# Altium schematic sheet 09_LCD&CAMERA&GPIO.SchDoc
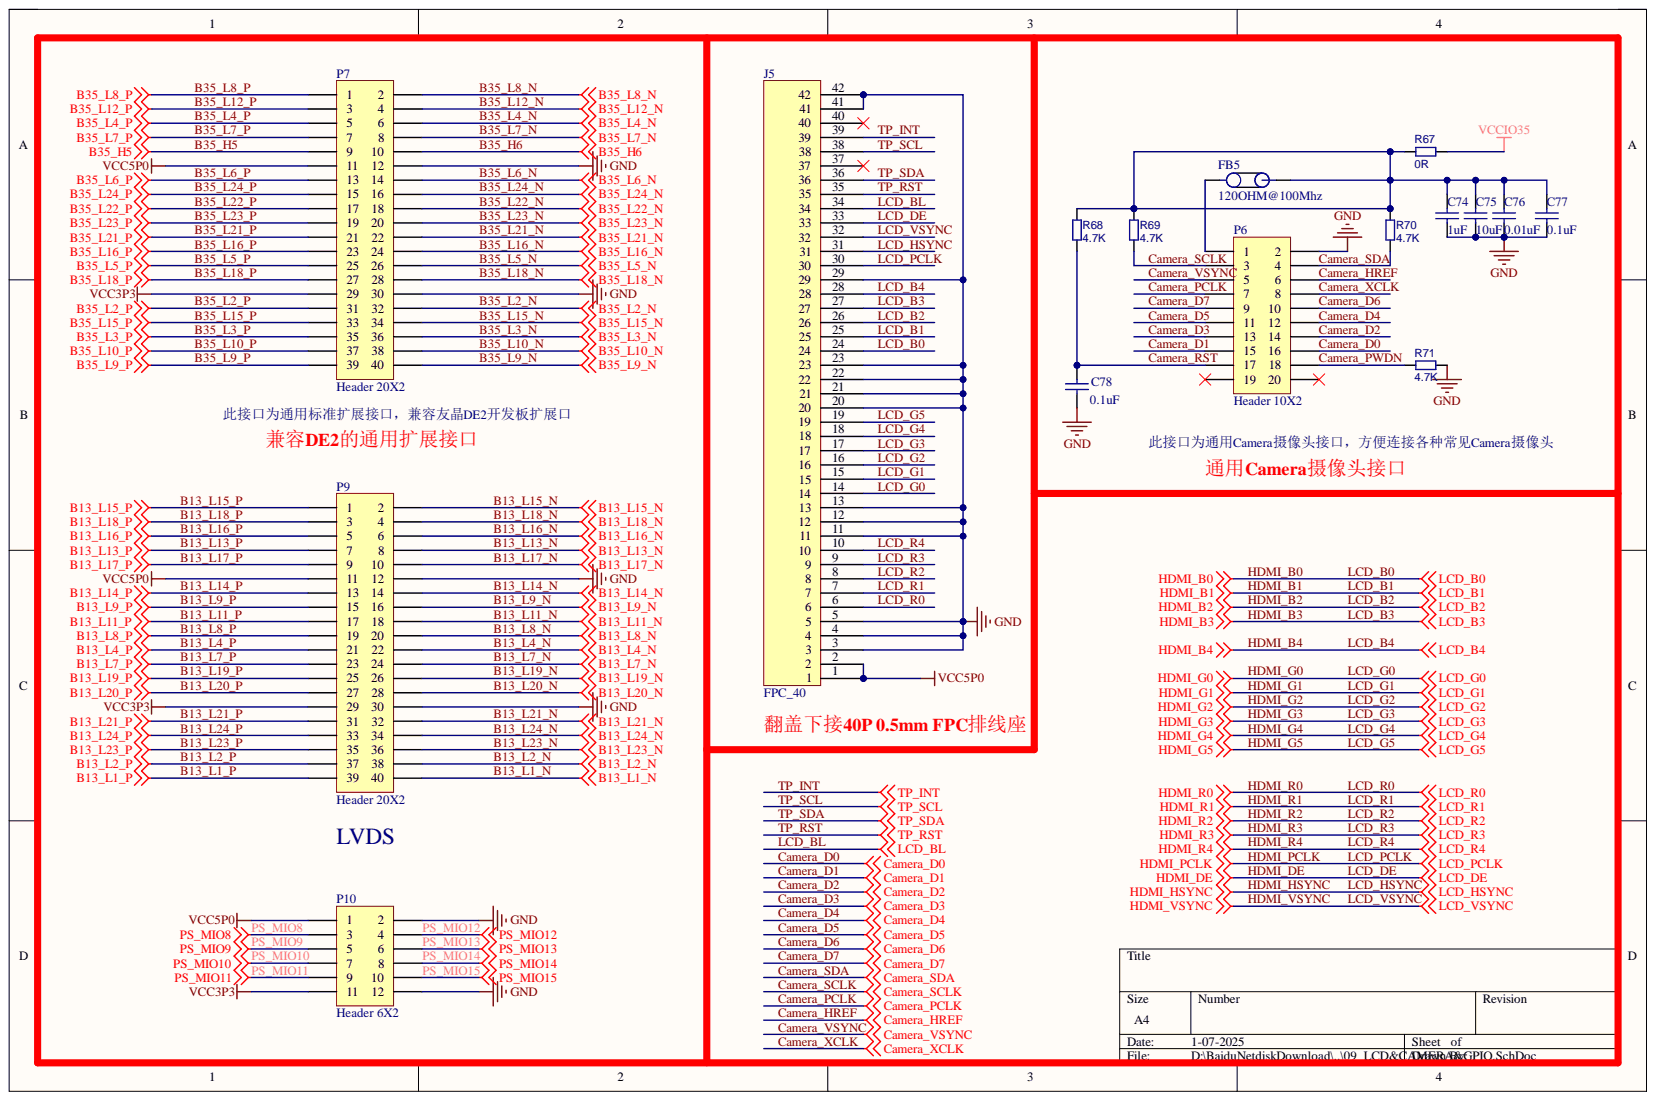
[diagram p0: 09_LCD&CAMERA&GPIO.SchDoc]

## Parts
C74  1uF  [C0603]
C75  10uF  [C0805]
C76  0.01uF  [C0603]
C77  0.1uF  [C0603]
C78  0.1uF  [C0603]
FB5  120OHM@100Mhz  [L0603]
J5  FPC_40  [CNN40_1R00_FP05SL_V]
P6  Header 10X2  [HD20-100-R]
P7  Header 20X2  [HDR2X20]
P9  Header 20X2  [HDR2X20]
P10  Header 6X2  [HDR2X6]
R67  0R  [R0603]
R68  4.7K  [0603R]
R69  4.7K  [0603R]
R70  4.7K  [0603R]
R71  4.7K  [0603R]

## Nets
GND: C74.1 C75.1 C76.1 C77.1 C78.1 J5.3 J5.4 J5.5 J5.11 J5.12 J5.13 J5.20 J5.21 J5.22 J5.23 J5.29 J5.41 J5.42 P6.2 P7.12 P7.30 P9.12 P9.30 P10.2 P10.12 R71.2
VCC3P3: P7.29 P9.29 P10.11
VCC5P0: J5.1 J5.2 P7.11 P9.11 P10.1
VCCIO35: R67.2
B13_L1_N: P9.40
B13_L1_P: P9.39
B13_L2_N: P9.38
B13_L2_P: P9.37
B13_L4_N: P9.22
B13_L4_P: P9.21
B13_L7_N: P9.24
B13_L7_P: P9.23
B13_L8_N: P9.20
B13_L8_P: P9.19
B13_L9_N: P9.16
B13_L9_P: P9.15
B13_L11_N: P9.18
B13_L11_P: P9.17
B13_L13_N: P9.8
B13_L13_P: P9.7
B13_L14_N: P9.14
B13_L14_P: P9.13
B13_L15_N: P9.2
B13_L15_P: P9.1
B13_L16_N: P9.6
B13_L16_P: P9.5
B13_L17_N: P9.10
B13_L17_P: P9.9
B13_L18_N: P9.4
B13_L18_P: P9.3
B13_L19_N: P9.26
B13_L19_P: P9.25
B13_L20_N: P9.28
B13_L20_P: P9.27
B13_L21_N: P9.32
B13_L21_P: P9.31
B13_L23_N: P9.36
B13_L23_P: P9.35
B13_L24_N: P9.34
B13_L24_P: P9.33
B35_H5: P7.9
B35_H6: P7.10
B35_L2_N: P7.32
B35_L2_P: P7.31
B35_L3_N: P7.36
B35_L3_P: P7.35
B35_L4_N: P7.6
B35_L4_P: P7.5
B35_L5_N: P7.26
B35_L5_P: P7.25
B35_L6_N: P7.14
B35_L6_P: P7.13
B35_L7_N: P7.8
B35_L7_P: P7.7
B35_L8_N: P7.2
B35_L8_P: P7.1
B35_L9_N: P7.40
B35_L9_P: P7.39
B35_L10_N: P7.38
B35_L10_P: P7.37
B35_L12_N: P7.4
B35_L12_P: P7.3
B35_L15_N: P7.34
B35_L15_P: P7.33
B35_L16_N: P7.24
B35_L16_P: P7.23
B35_L18_N: P7.28
B35_L18_P: P7.27
B35_L21_N: P7.22
B35_L21_P: P7.21
B35_L22_N: P7.18
B35_L22_P: P7.17
B35_L23_N: P7.20
B35_L23_P: P7.19
B35_L24_N: P7.16
B35_L24_P: P7.15
Camera_D0: P6.16
Camera_D1: P6.15
Camera_D2: P6.14
Camera_D3: P6.13
Camera_D4: P6.12
Camera_D5: P6.11
Camera_D6: P6.10
Camera_D7: P6.9
Camera_HREF: P6.6
Camera_PCLK: P6.7
Camera_PWDN: P6.18 R71.1
Camera_RST: C78.2 P6.17 R68.2
Camera_SCLK: P6.3 R69.2
Camera_SDA: P6.4 R70.2
Camera_VSYNC: P6.5
Camera_XCLK: P6.8
HDMI_B0: J5.24
HDMI_B1: J5.25
HDMI_B2: J5.26
HDMI_B3: J5.27
HDMI_B4: J5.28
HDMI_DE: J5.33
HDMI_G0: J5.14
HDMI_G1: J5.15
HDMI_G2: J5.16
HDMI_G3: J5.17
HDMI_G4: J5.18
HDMI_G5: J5.19
HDMI_HSYNC: J5.31
HDMI_PCLK: J5.30
HDMI_R0: J5.6
HDMI_R1: J5.7
HDMI_R2: J5.8
HDMI_R3: J5.9
HDMI_R4: J5.10
HDMI_VSYNC: J5.32
LCD_BL: J5.34
PS_MIO8: P10.3
PS_MIO9: P10.5
PS_MIO10: P10.7
PS_MIO11: P10.9
PS_MIO12: P10.4
PS_MIO13: P10.6
PS_MIO14: P10.8
PS_MIO15: P10.10
TP_INT: J5.39
TP_RST: J5.35
TP_SCL: J5.38
TP_SDA: J5.36
NetC74_2: C74.2 C75.2 C76.2 C77.2 FB5.2 R67.1 R68.1 R69.1 R70.1
NetFB5_1: FB5.1 P6.1

Not connected: J5 37 40; P6 19 20

## Library data of the parts
C74-C78 = Cap: Capacitor; Component Kind: Standard; LatestRevisionDate: 17-Jul-2002; LatestRevisionNote: Re-released for DXP Platform.; Library Name: Miscellaneous Devices.IntLib; PackageDescription: Radial Cap, Thru-Hole; 2 Leads; 0.3 in Pin Spacing; PackageReference: RAD-0.3; Published: 8-Jun-2000; Signal Integrity: Cap; Simulation: CAP; Publisher: Altium Limited
  C74: Value: 1uF
  C75: Value: 10uF
  C76: Value: 0.01uF
  C77 C78: Value: 0.1uF
FB5 = CiZhu: Component Kind: Standard; Library Name: user.SchLib; Value: 120OHM@100Mhz
J5 = h_40: Component Kind: Standard; Library Name: NEW.SchLib
P6 = Header 10X2: 2.54mm，弯针，2*10，排母; Component Kind: Standard; LatestRevisionDate: 17-Jul-2002; LatestRevisionNote: Re-released for DXP Platform.; Library Name: Miscellaneous Connectors.IntLib; Signal Integrity: Connector; Publisher: Altium Limited
P7 P9 = Header 20X2: IDC3-40，2.54mm，双排2*20简牛; Component Kind: Standard; LatestRevisionDate: 17-Jul-2002; LatestRevisionNote: Re-released for DXP Platform.; Library Name: Miscellaneous Connectors.IntLib; Signal Integrity: Connector; Publisher: Altium Limited
P10 = Header 6X2: Header, 6-Pin, Dual row; Component Kind: Standard; LatestRevisionDate: 17-Jul-2002; LatestRevisionNote: Re-released for DXP Platform.; Library Name: Miscellaneous Connectors.IntLib; Signal Integrity: Connector; Publisher: Altium Limited
R67-R71 = R: Component Kind: Standard; Library Name: 无极性.SchLib
